# Altium schematic sheet Thermocouple Interface.SchDoc("Thermocouple Interface")
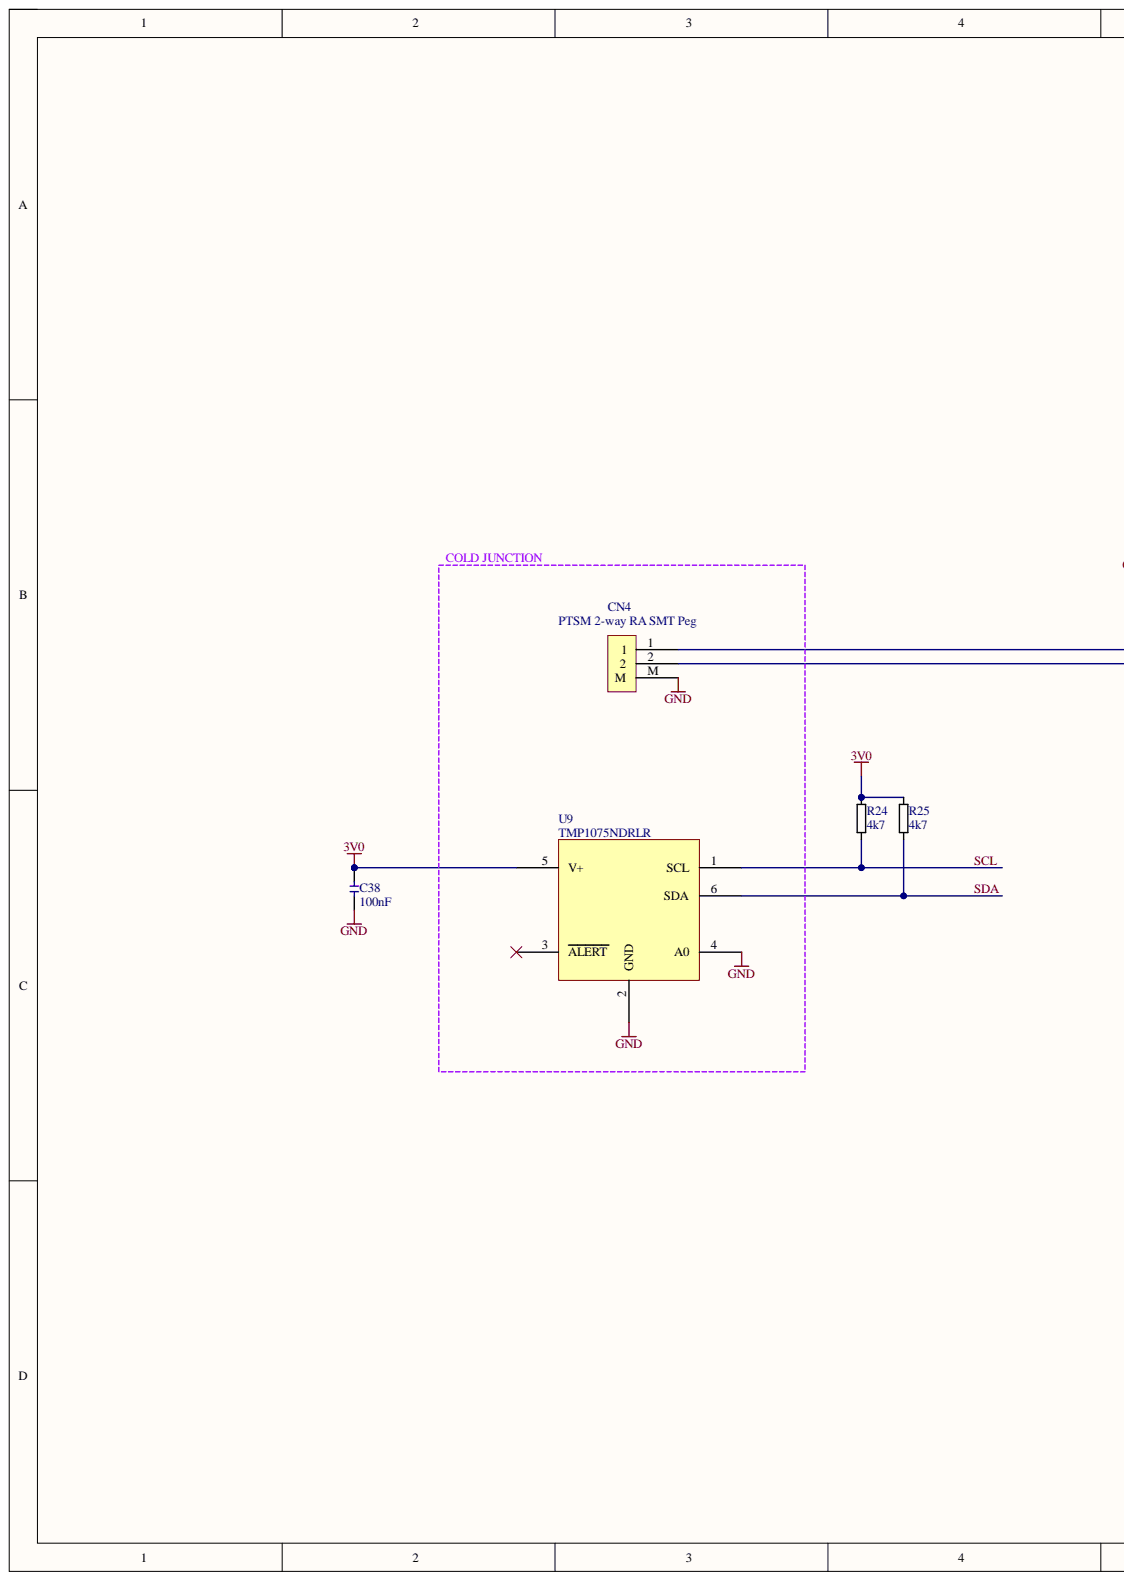
[diagram p0: Thermocouple Interface.SchDoc, left]
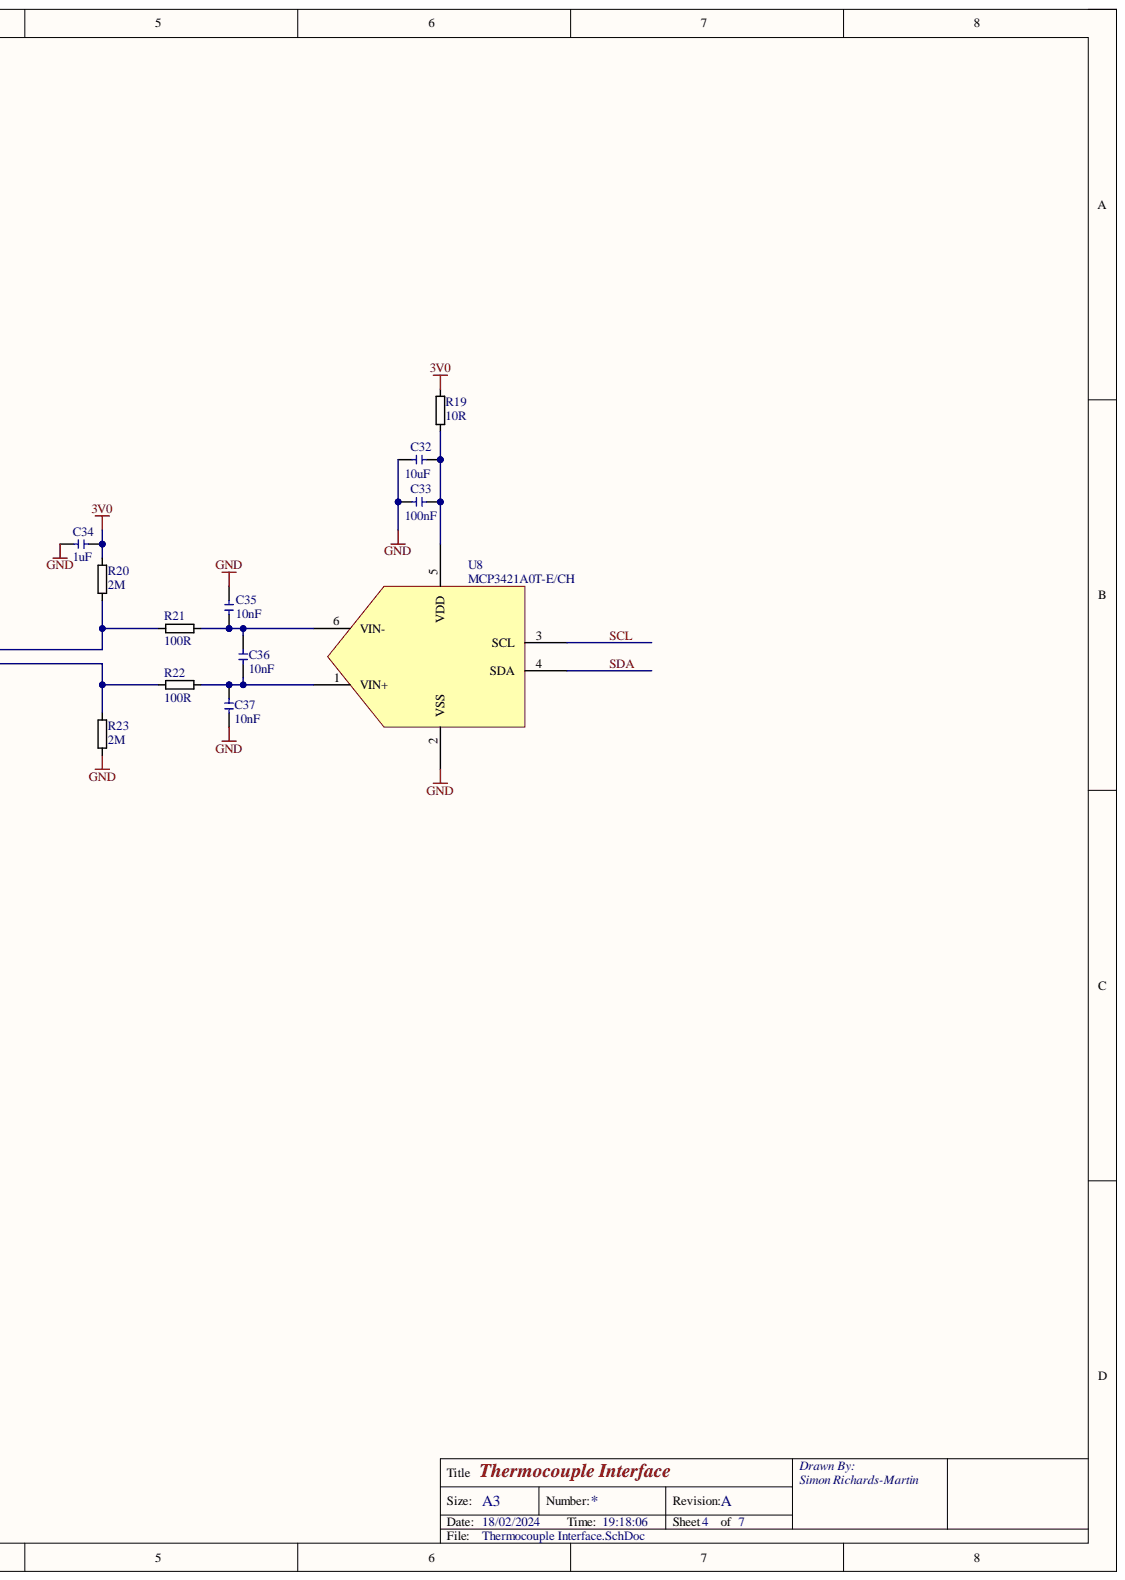
[diagram p1: Thermocouple Interface.SchDoc, right]

## Parts
C32  10uF  [CHIP-0402]
C33  100nF  [CHIP-0402]
C34  1uF  [CHIP-0402]
C35  10nF  [CHIP-0402]
C36  10nF  [CHIP-0402]
C37  10nF  [CHIP-0402]
C38  100nF  [CHIP-0402]
CN4  PTSM 2-way RA SMT Peg  [1778764]
R19  10R  [CHIP-0402]
R20  2M  [CHIP-0402]
R21  100R  [CHIP-0402]
R22  100R  [CHIP-0402]
R23  2M  [CHIP-0402]
R24  4k7  [CHIP-0402]
R25  4k7  [CHIP-0402]
U8  MCP3421A0T-E/CH  [SOT23-6]
U9  TMP1075NDRLR  [SOT563-6]

## Nets
3V0: C34.2 C38.1 R19.2 R20.2 R24.2 R25.2 U9.5(V+)
GND: C32.1 C33.1 C34.1 C35.1 C37.2 C38.2 CN4.M R23.1 U8.2(VSS) U9.2(GND) U9.4(A0)
SCL: R24.1 U8.3(SCL) U9.1(SCL)
SDA: R25.1 U8.4(SDA) U9.6(SDA)
NetC32_2: C32.2 C33.2 R19.1 U8.5(VDD)
NetC35_2: C35.2 C36.1 R21.2 U8.6(VIN-)
NetC36_2: C36.2 C37.1 R22.2 U8.1(VIN+)
NetCN4_1: CN4.1 R20.1 R21.1
NetCN4_2: CN4.2 R22.1 R23.2

Not connected: U9 3(ALERT)

## Library data of the parts
C32 = Capacitor 10uF 10V 0402 X5R: Manufacturer: Murata; MPN: GRM155R61A106ME11D; Component Kind: Standard; Description: Capacitor 10uF 10V 0402 X5R; Library Name: Paramotor Sensor Main.SchLib
C33 C38 = Capacitor 100nF 50V 0402 X5R: Manufacturer: Murata; MPN: GRM155R61H104KE14D; Component Kind: Standard; Description: Capacitor 100nF 50V 0402 X5R; Library Name: Paramotor Sensor Main.SchLib
C34 = Capacitor 1uF 50V 0402 X5R: Manufacturer: Murata; MPN: GRM155R61H105KE05D; Component Kind: Standard; Description: Capacitor 1uF 50V 0402 X5R; Library Name: Paramotor Sensor Main.SchLib
C35-C37 = Capacitor 10nF 25V 0402 C0G: Manufacturer: Murata; MPN: GRM1555C1E103GE01D; Component Kind: Standard; Description: Capacitor 10nF 25V 0402 C0G; Library Name: Paramotor Sensor Main.SchLib
CN4 = PTSM 2-way RA SMT Peg: Manufacturer: Phoenix Contact; Component Kind: Standard; MPN: 1778764; Library Name: Paramotor Sensor Main.SchLib
R19 = Resistor 10R 0402: Resistor 10R 1% 0402; Manufacturer: Yageo; MPN: RC0402FR-1310RL; Component Kind: Standard; Library Name: Paramotor Sensor Main.SchLib
R20 R23 = Resistor 2M 1% 0402: Manufacturer: Yageo; MPN: RC0402FR-072ML; Component Kind: Standard; Description: Resistor 2M 1% 0402; Library Name: Paramotor Sensor Main.SchLib
R21 R22 = Resistor 100R 1% 0402: Manufacturer: Yageo; MPN: AC0402FR-07100RL; Component Kind: Standard; Description: Resistor 100R 1% 0402; Library Name: Paramotor Sensor Main.SchLib
R24 R25 = Resistor 4.7k 1% 0402: Manufacturer: Yageo; MPN: AC0402FR-134K7L; Component Kind: Standard; Description: Resistor 4.7k 1% 0402; Library Name: Paramotor Sensor Main.SchLib
U8 = MCP3421A0T-E/CH: ADC 18-bit with Vref Delta Sigma I2C SOT23-6; Manufacturer: Microchip; Component Kind: Standard; MPN: MCP3421A0T-E/CH; Library Name: Paramotor Sensor Main.SchLib
U9 = TMP1075NDRLR: Temp Sens. I2C +/-1C SOT563-6; Manufacturer: Texas Instruments; Component Kind: Standard; MPN: TMP1075NDRLR; Library Name: Paramotor Sensor Main.SchLib
